# Altium schematic sheet CAN Breakout.SchDoc
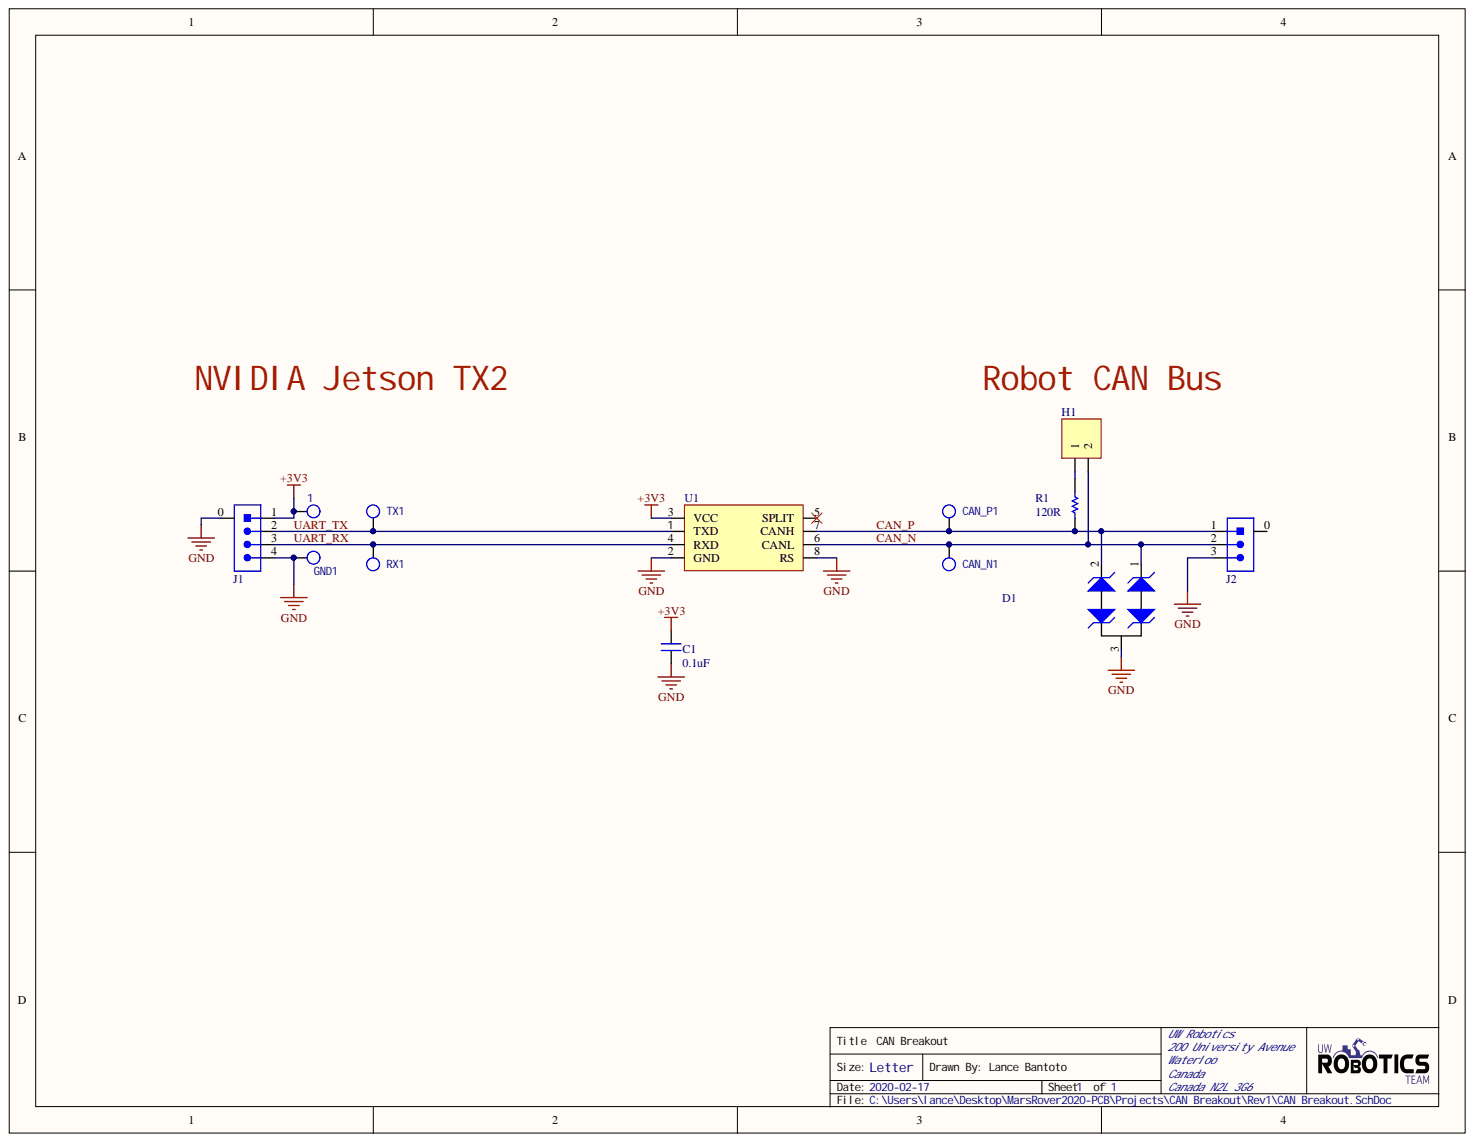
[diagram p0: CAN Breakout.SchDoc]

## Parts
1  TESTPOINT_LOOP  [TESTPOINT_LOOP]  (value not drawn)
C1  value 0.1uF  [CAPC0603(1608)55_N]  (hidden comment: CAP_0.1uF_50V_0603)
CAN_N1  TESTPOINT_LOOP  [TESTPOINT_LOOP]  (value not drawn)
CAN_P1  TESTPOINT_LOOP  [TESTPOINT_LOOP]  (value not drawn)
D1  TVS_DIODE_CAN  [TVS_DIODE_CAN]  (value not drawn)
GND1  TESTPOINT_LOOP  [TESTPOINT_LOOP]  (value not drawn)
H1  JUMPER_2  [HDR1X2]  (value not drawn)
J1  CON_4M_SM4  [JST-B4B-SM4-TB]  (value not drawn)
J2  CON_3M_SM4  [JST-B3B-SM4-TB]  (value not drawn)
R1  value 120R  [RESC0603(1608)_N]  (hidden comment: RES_120R_0603)
RX1  TESTPOINT_LOOP  [TESTPOINT_LOOP]  (value not drawn)
TX1  TESTPOINT_LOOP  [TESTPOINT_LOOP]  (value not drawn)
U1  IC TXRX CAN  [LT-S8_N]  (value not drawn)

## Nets
+3V3: 1.1 C1.2 J1.1 U1.3(VCC)
GND: C1.1 D1.3 GND1.1 J1.0 J1.4 J2.3 U1.2(GND) U1.8(RS)
CAN_N: CAN_N1.1 D1.1 H1.2 J2.2 U1.6(CANL)
CAN_P: CAN_P1.1 D1.2 J2.1 R1.1 U1.7(CANH)
UART_RX: J1.3 RX1.1 U1.4(RXD)
UART_TX: J1.2 TX1.1 U1.1(TXD)
NetH1_1: H1.1 R1.2

Not connected: J2 0; U1 5(SPLIT)

## Library data of the parts
1 CAN_N1 CAN_P1 GND1 RX1 TX1 = TESTPOINT_LOOP: Loop testpoint through-hole pad; Manufacturer 1: Keystone Electronics; Manufacturer Part Number 1: 5002; Component Kind: Standard; Mount: Through Hole; Supplier 1: Digi-Key; Supplier Part Number 1: 36-5002-ND; Library Name: UWRT_Schematic_Library_2020.SchLib
C1 = CAP_0.1uF_50V_0603: CAP CER 0.1UF 50V X7R 0603; Manufacturer 1: Yageo; Manufacturer Part Number 1: CC0603KRX7R9BB104; Component Kind: Standard; Dimensions: 0.063" L x 0.031" W (1.60mm x 0.80mm); Mount: Surface Mount; Package: 0603 (1608 Metric); Signal Integrity: Cap; Simulation: CAP; Supplier 1: Digi-Key; Supplier Part Number 1: 311-1344-1-ND; Temperature Coefficient: X7R; Voltage Rating: 50V; Library Name: UWRT_Schematic_Library_2020.SchLib
D1 = TVS_DIODE_CAN: TVS DIODE 24V 41V SOT323-3; Manufacturer 1: Nexperia USA Inc.; Manufacturer Part Number 1: PESD2CAN,215; Component Kind: Standard; ComponentLink1Description: Datasheet; ComponentLink1URL: https://assets.nexperia.com/documents/data-sheet/PESD1CAN-U.pdf; Mount: Surface Mount; Package: SC-70, SOT-323; Supplier 1: Digi-Key; Supplier Part Number 1: 1727-3891-1-ND; Library Name: UWRT_Schematic_Library_2020.SchLib
H1 = JUMPER_2: HEADER 2X1; Manufacturer 1: TE Connectivity; Manufacturer Part Number 1: 87220-2; Component Kind: Standard; Mount: Through Hole; Supplier 1: Digi-Key; Supplier Part Number 1: A26542-ND; Library Name: UWRT_Schematic_Library_2020.SchLib
J1 = CON_4M_SM4: CONN HEADER SMD 4POS 2MM; Manufacturer 1: JST; Manufacturer Part Number 1: B4B-PH-SM4-TB(LF)(SN); Max Current Rating: 2A; Max Voltage Rating: 100V; Component Kind: Standard; ComponentLink1Description: https://datasheet.ciiva.com/8052/1699381-8052048.pdf?src-supplier=Element14; ComponentLink1URL: https://datasheet.ciiva.com/8052/1699381-8052048.pdf?src-supplier=Element14; ComponentLink2Description: https://datasheet.ciiva.com/11747/0900766b80ddea81-11747504.pdf?src-supplier=RSComponents; ComponentLink2URL: https://datasheet.ciiva.com/11747/0900766b80ddea81-11747504.pdf?src-supplier=RSComponents; ComponentLink3Description: https://datasheet.ciiva.com/6747/80567-6747409.pdf?src-supplier=Newark; ComponentLink3URL: https://datasheet.ciiva.com/6747/80567-6747409.pdf?src-supplier=Newark; ComponentLink4Description: https://datasheet.ciiva.com/727/eph-727525.pdf?src-supplier=Digi-Key; ComponentLink4URL: https://datasheet.ciiva.com/727/eph-727525.pdf?src-supplier=Digi-Key; ComponentLink5Description: https://datasheet.ciiva.com/712/eph-712582.pdf?src-supplier=Digi-Key; ComponentLink5URL: https://datasheet.ciiva.com/712/eph-712582.pdf?src-supplier=Digi-Key; ComponentLink6Description: https://datasheet.ciiva.com/712/eph-712583.pdf?src-supplier=Digi-Key; ComponentLink6URL: https://datasheet.ciiva.com/712/eph-712583.pdf?src-supplier=Digi-Key; Mount: Surface Mount; Pitch: 2mm; Supplier 1: Digi-Key; Supplier Part Number 1: 455-1736-1-ND; Library Name: UWRT_Schematic_Library_2020.SchLib
J2 = CON_3M_SM4: CONN HEADER SMD 3POS 2MM; Manufacturer 1: JST; Manufacturer Part Number 1: B3B-PH-SM4-TB(LF)(SN); Max Current Rating: 2A; Max Voltage Rating: 100V; Component Kind: Standard; ComponentLink1Description: https://datasheet.ciiva.com/11747/0900766b80ddea81-11747684.pdf?src-supplier=RSComponents; ComponentLink1URL: https://datasheet.ciiva.com/11747/0900766b80ddea81-11747684.pdf?src-supplier=RSComponents; Mount: Surface Mount; Pitch: 2mm; Supplier 1: Digi-Key; Supplier Part Number 1: 455-1735-1-ND; Library Name: UWRT_Schematic_Library_2020.SchLib
R1 = RES_120R_0603: RES SMD 120 OHM 5% 1/10W 0603; Manufacturer 1: Yageo; Manufacturer Part Number 1: RC0603JR-07120RL; Component Kind: Standard; Dimensions: 0.063" L x 0.031" W (1.60mm x 0.80mm); Mount: Surface Mount; Package: 0603 (1608 Metric); Signal Integrity: Res; Simulation: RESISTOR; Supplier 1: Digi-Key; Supplier Part Number 1: 311-120GRCT-ND; Tolerance: 5%; Library Name: UWRT_Schematic_Library_2020.SchLib
U1 = LTC2875: IC TXRX CAN 4MBPS 8SO; Manufacturer 1: Linear Technologies; Manufacturer Part Number 1: LTC2875IS8#PBF; Component Kind: Standard; ComponentLink1Description: Datasheet; ComponentLink1URL: https://www.analog.com/media/en/technical-documentation/data-sheets/2875f.pdf; Mount: Surface Mount; Package: 8-SOIC (0.154", 3.90mm Width); Supplier 1: Digi-Key; Supplier Part Number 1: LTC2875IS8#PBF-ND; Library Name: UWRT_Schematic_Library_2020.SchLib
